# Altium schematic sheet SD-Card.SchDoc(U_SD-Card)
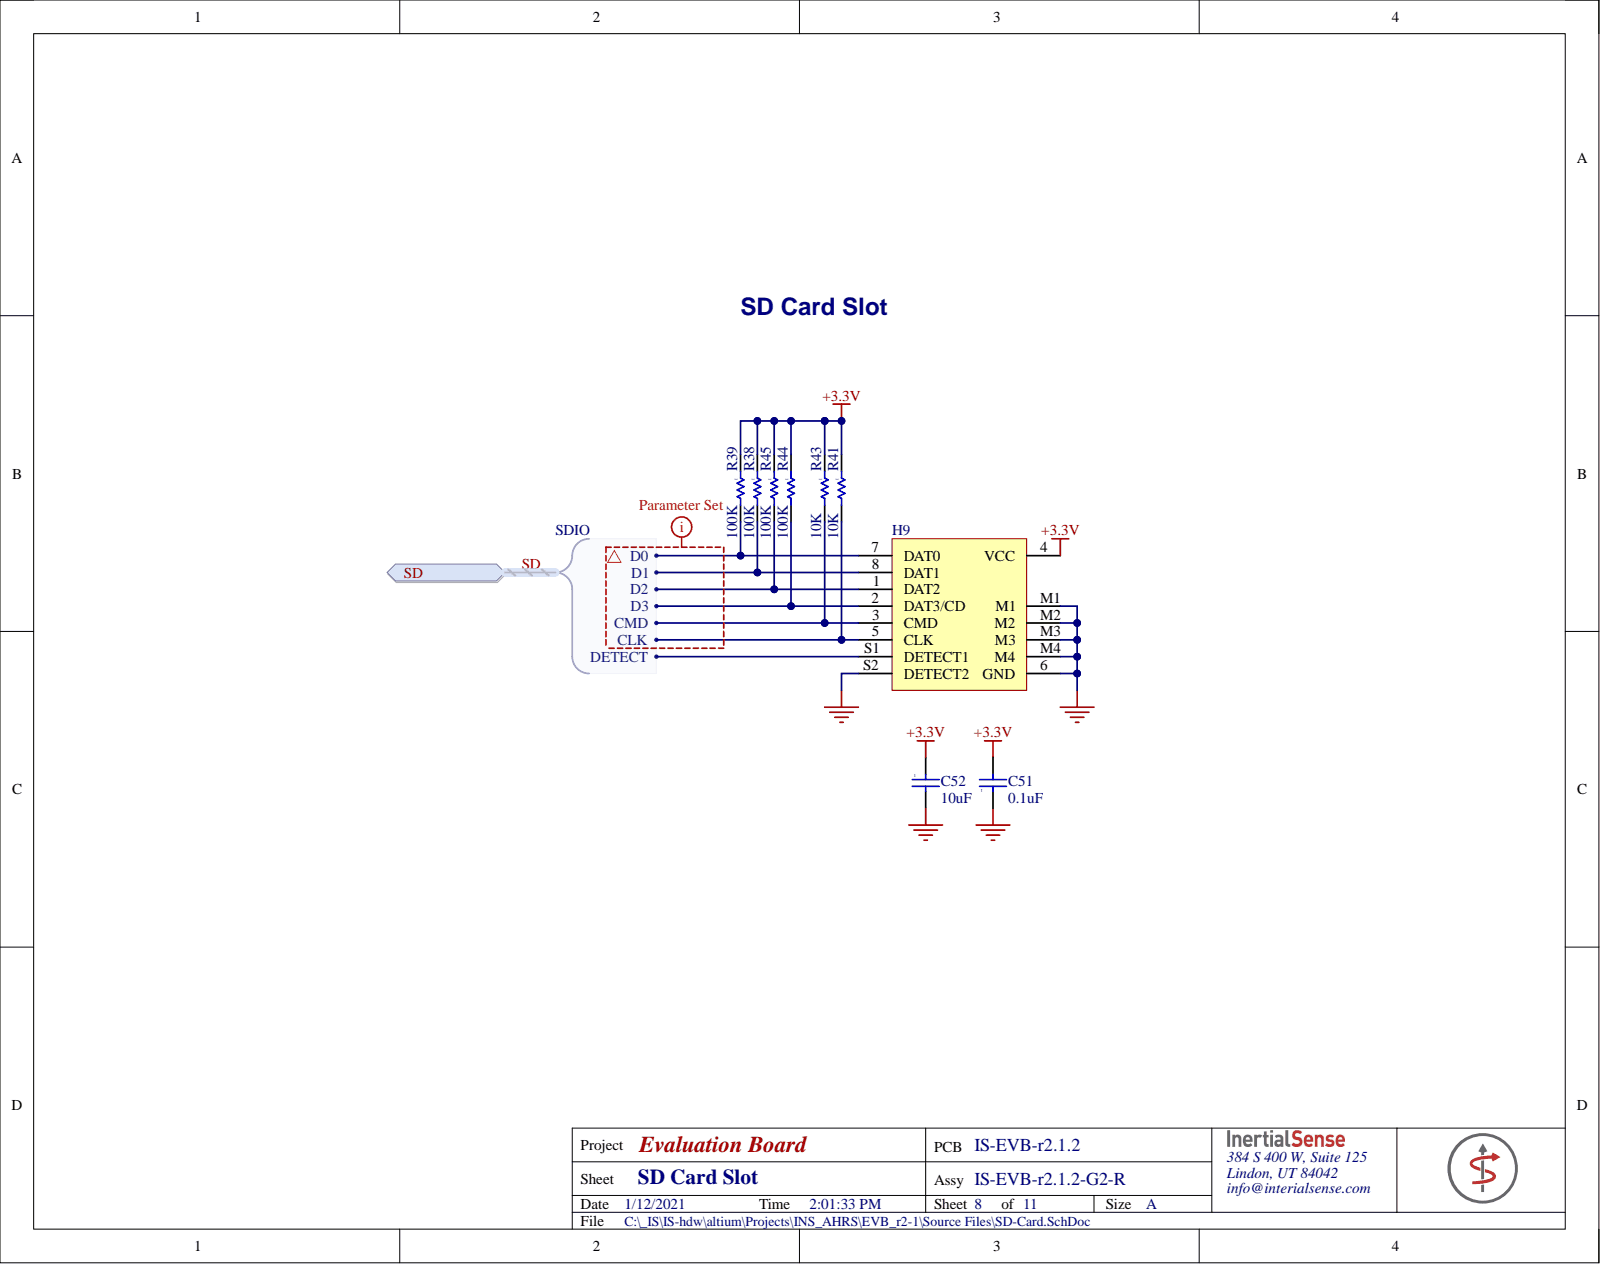
[diagram p0: SD-Card.SchDoc]

## Parts
C51  value 0.1uF  [0402]  (hidden comment: Cap)
C52  value 10uF  Capacitance: 10uF  [0805]  (hidden comment: Cap)
H9  SD Card Socket  [114-00841-68]  (value not drawn)
R38  value 100K  [R0402]  (hidden comment: Res)
R39  value 100K  [R0402]  (hidden comment: Res)
R41  value 10K  [R0402]  (hidden comment: Res)
R43  value 10K  [R0402]  (hidden comment: Res)
R44  value 100K  [R0402]  (hidden comment: Res)
R45  value 100K  [R0402]  (hidden comment: Res)

## Ports
SD, SD.CLK, SD.CMD, SD.D0, SD.D1, SD.D2, SD.D3, SD.DETECT

## Nets
+3.3V: C51.2 C52.1 H9.4(VCC) R38.1 R39.1 R41.1 R43.1 R44.1 R45.1
GND: C51.1 C52.2 H9.6(GND) H9.M1 H9.M2 H9.M3 H9.M4 H9.S2(DETECT2)
SD.CLK: H9.5(CLK) R41.2 ; port SD
SD.CMD: H9.3(CMD) R43.2 ; port SD
SD.D0: H9.7(DAT0) R39.2 ; port SD
SD.D1: H9.8(DAT1) R38.2 ; port SD
SD.D2: H9.1(DAT2) R45.2 ; port SD
SD.D3: H9.2(DAT3/CD) R44.2 ; port SD
SD.DETECT: H9.S1(DETECT1) ; port SD

## Library data of the parts
C51 = Cap: CAP CER .10UF 50V X7R 10% 0402; Manufacturer: TDK Corporation; Manufacturer Part Number: C1005X7R1H104K; Capacitance: 0.1µF; Applications: General Purpose; Category: Capacitors; Component Kind: Standard; ComponentLink1Description: http://www.tdk.co.jp/tefe02/e412_c.pdf; ComponentLink1URL: http://www.tdk.co.jp/tefe02/e412_c.pdf; Features: -; Height: -; LatestRevisionDate: 17-Jul-2002; LatestRevisionNote: Re-released for DXP Platform.; Lead Spacing: -; Lead Style: -; Library Name: General.SchLib; Mounting Type: Surface Mount, MLCC; Operating Temperature: -55°C ~ 125°C; Package / Case: 0402 (1005 Metric); Packaging: Cut Tape (CT); Pricing 1: 1=0.3, 10=0.2, 100=0.08, 250=0.05752, 500=0.041, 1000=0.03 (USD); Published: 8-Jun-2000; Ratings: -; RoHS: RoHS Compliant; Signal Integrity: Cap; Simulation: CAP; Size / Dimension: 0.039" L x 0.020" W (1.00mm x 0.50mm); Stock 1: 174080; Supplier 1: Digi-Key; Supplier Part Number 1: 445-5932-1-ND; Temperature Coefficient: X7R; Thickness: 0.022" (0.55mm); Tolerance: ±10%; Voltage - Rated: 50V; Publisher: Altium Limited
C52 = Cap: CAP CER 10UF 35V X5R 0805; Manufacturer: TDK; Manufacturer Part Number: C2012X5R1V106K085AC; Dielectric Material: Ceramic; Tolerance: 10%; Case Code (Imperial): 0805; Case Code (Metric): 2012; Case/Package: 0805; Category: Capacitors; Component Kind: Standard; ComponentLink1Description: https://datasheet.ciiva.com/14894/tdkmlcccaprange-14894527.pdf; ComponentLink1URL: https://datasheet.ciiva.com/14894/tdkmlcccaprange-14894527.pdf; Dielectric: X5R; Height: 0.85mm; LatestRevisionDate: 17-Jul-2002; LatestRevisionNote: Re-released for DXP Platform.; Lead Free: Lead Free; Length: 0.079inch; Library Name: General.SchLib; Max Operating Temperature: 85°C; Min Operating Temperature: -55°C; Mount: Surface Mount; Package Quantity: 1; Packaging: Tape and Reel; Pricing 1: 1=0.83, 10=0.589, 100=0.3881, 500=0.2875, 1000=0.24438 (USD); Published: 8-Jun-2000; REACH SVHC: No SVHC; Signal Integrity: Cap; Simulation: CAP; Stock 1: 0; Supplier 1: Digi-Key; Supplier Part Number 1: 445-14417-6-ND; Termination: SMD/SMT; Thickness: 0.039inch; Voltage Rating: 35V; Voltage Rating (DC): 35V; Weight: 0.000194oz; Width: 0.049inch; Publisher: Altium Limited
H9 = SD Card Socket: CONN MICRO SD CARD PUSH-PULL R/A; Manufacturer: Amphenol Commercial; Connector Type: Connector; Gender: Receptacle; Number of Contacts: 8; Pitch: 1.1mm; Component Kind: Standard; ComponentLink1Description: https://datasheet.ciiva.com/683/114-00841-68-683584.pdf; ComponentLink1URL: https://datasheet.ciiva.com/683/114-00841-68-683584.pdf; ComponentLink2Description: https://datasheet.ciiva.com/24241/11400841-283410-24241569.pdf; ComponentLink2URL: https://datasheet.ciiva.com/24241/11400841-283410-24241569.pdf; ComponentLink3Description: https://datasheet.ciiva.com/28408/11400841-28408193.pdf; ComponentLink3URL: https://datasheet.ciiva.com/28408/11400841-28408193.pdf; ComponentLink4Description: https://datasheet.ciiva.com/9464/1140084168-9464901.pdf; ComponentLink4URL: https://datasheet.ciiva.com/9464/1140084168-9464901.pdf; ComponentLink5Description: https://datasheet.ciiva.com/48965/70323450-48965706.pdf; ComponentLink5URL: https://datasheet.ciiva.com/48965/70323450-48965706.pdf; ComponentLink6Description: https://datasheet.ciiva.com/16655/1140084168-16655293.pdf; ComponentLink6URL: https://datasheet.ciiva.com/16655/1140084168-16655293.pdf; Contact Material: Copper,Copper Alloy; Contact Plating: Gold; Depth: 11.45mm; Flammability Rating: UL94 V-0; Height: 1.55mm; Housing Material: Polymer; Lead Free: Lead Free; Length: 15.6mm; Library Name: Connectors.SchLib; Manufacturer Part Number: 114-00841-68; Mount: Surface Mount; Number of Rows: 1; Orientation: Right Angle; Package Quantity: 1; Packaging: Tape and Reel; Pricing 1: 1=1.31, 10=1.188, 25=1.1156, 50=1.0672, 100=1.0187, 250=0.92168, 500=0.84892 (USD); Radiation Hardening: No; REACH SVHC: Unknown; Stock 1: 42391; Supplier 1: Digi-Key; Supplier Part Number 1: 114-00841-68-6-ND; Termination: SMD/SMT
R38 R39 R44 R45 = Res: RES SMD 100K OHM 1% 1/16W 0402; Manufacturer: Panasonic; Manufacturer Part Number: ERJ-2RKF1003X; Max Power Dissipation: 100mW; Resistance: 100kR; Temperature Coefficient: 100ppm/°C; Voltage Rating: 50V; Case Code (Imperial): 0402; Case Code (Metric): 1005; Case/Package: 0402; Component Kind: Standard; ComponentLink1Description: http://www.seielect.com/Catalog/SEI-RMCF_RMCP.pdf; ComponentLink1URL: http://www.seielect.com/Catalog/SEI-RMCF_RMCP.pdf; Composition: Thick Film; Contact Plating: Tin; Depth: 0.5mm; Features: Automotive AEC-Q200; Height: 0.35mm; Lead Free: Lead Free; Length: 1mm; Library Name: General.SchLib; Max Operating Temperature: 155°C; Military Standard: Not; Min Operating Temperature: -55°C; Mount: Surface Mount; Number of Pins: 2; Number of Terminations: 2; Package Quantity: 1; Packaging: Tape and Reel; Power Rating: 100mW; Pricing 1: 1=0.1, 10=0.045, 100=0.0184, 1000=0.00826, 2500=0.00717, 5000=0.00593 (USD); Radiation Hardening: No; REACH SVHC: No SVHC; Signal Integrity: Res; Simulation: RES; Stock 1: 6572; Supplier 1: Digi-Key; Supplier Part Number 1: P100KLDKR-ND; Termination: SMD/SMT; Tolerance: 1%; Voltage Rating (DC): 50V; Width: 0.5mm
R41 R43 = Res: RES 10K OHM 1/16W 1% 0402 SMD; Manufacturer: Panasonic; Manufacturer Part Number: ERJ-2RKF1002X; Max Power Dissipation: 100mW; Resistance: 10kR; Temperature Coefficient: 100ppm/°C; Voltage Rating: 50V; Case Code (Imperial): 0402; Case Code (Metric): 1005; Case/Package: 0402; Component Kind: Standard; ComponentLink1Description: http://industrial.panasonic.com/www-cgi/jvcr13pz.cgi?E+PZ+3+AOA0002+ERJ2RKF1002X+7+WW; ComponentLink1URL: http://industrial.panasonic.com/www-cgi/jvcr13pz.cgi?E+PZ+3+AOA0002+ERJ2RKF1002X+7+WW; Composition: Thick Film; Contact Plating: Tin; Depth: 0.5mm; Features: Automotive AEC-Q200; Height: 0.35mm; Lead Free: Lead Free; Length: 1mm; Library Name: General.SchLib; Max Operating Temperature: 155°C; Military Standard: Not; Min Operating Temperature: -55°C; Mount: Surface Mount; Number of Pins: 2; Number of Terminations: 2; Package Quantity: 1; Packaging: Tape and Reel; Power Rating: 100mW; Pricing 1: 1=0.1, 10=0.045, 100=0.0184, 1000=0.00826, 2500=0.00717, 5000=0.00593 (USD); Radiation Hardening: No; REACH SVHC: No SVHC; Signal Integrity: Res; Simulation: RES; Stock 1: 28312782; Supplier 1: Digi-Key; Supplier Part Number 1: P10.0KLDKR-ND; Termination: SMD/SMT; Tolerance: 1%; Voltage Rating (DC): 50V; Width: 0.02inch
